# Altium schematic sheet CN032-1 Industry EtherCAT Servo Controller_MCU2.SchDoc
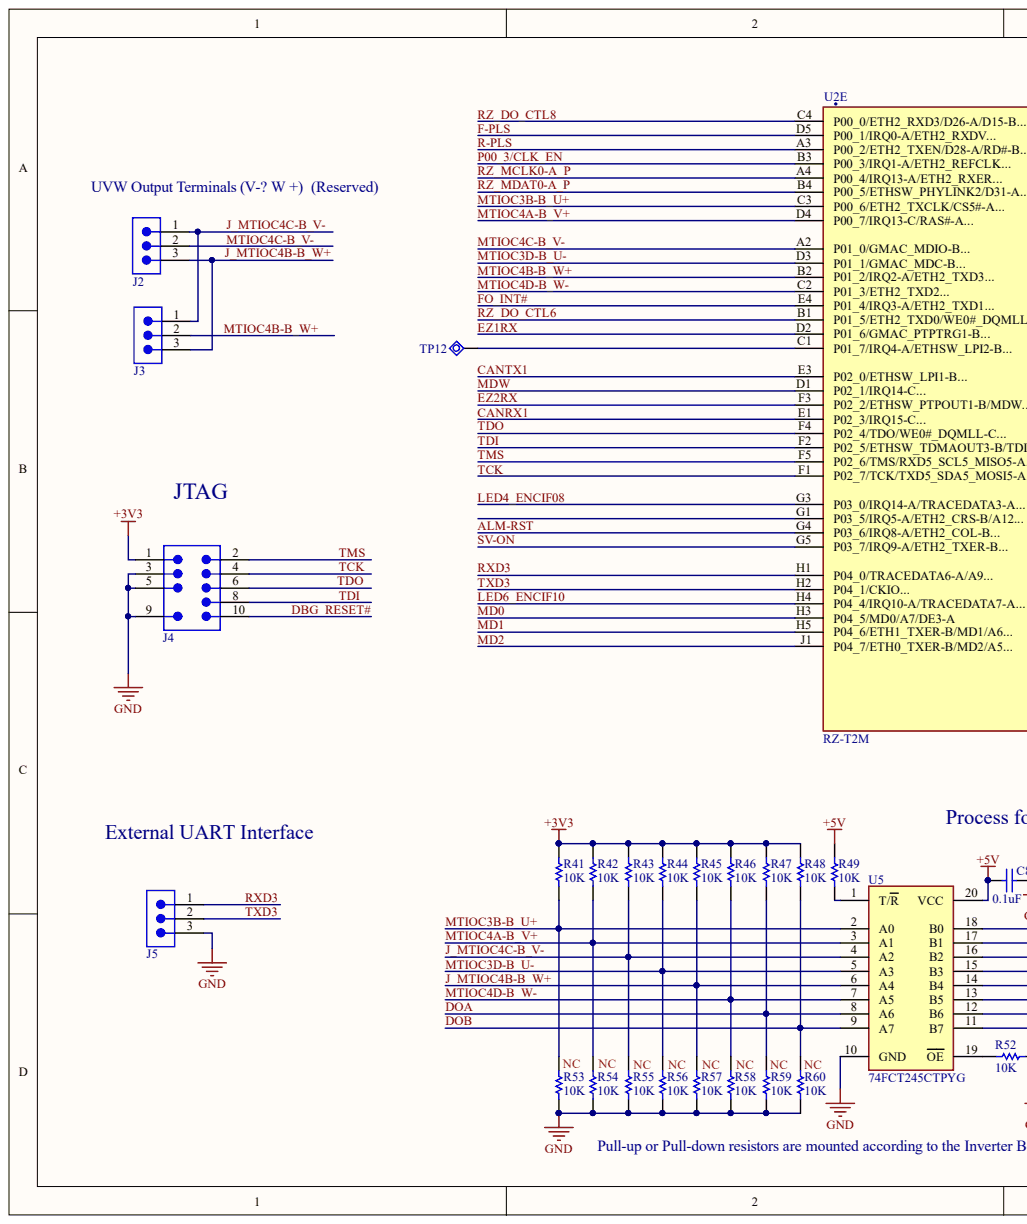
[diagram p0: CN032-1 Industry EtherCAT Servo Controller_MCU2.SchDoc, left]
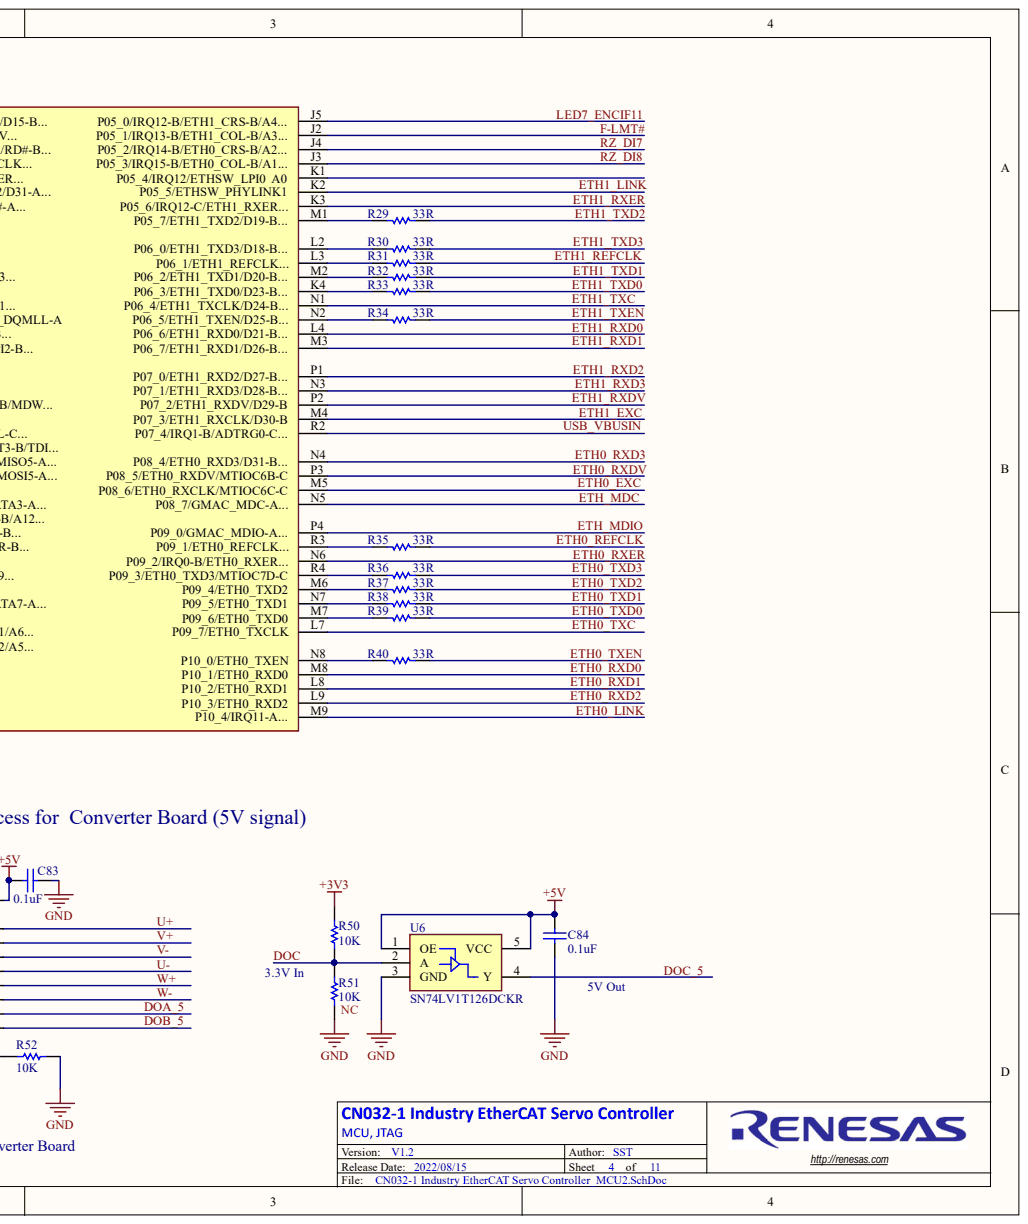
[diagram p1: CN032-1 Industry EtherCAT Servo Controller_MCU2.SchDoc, right]

## Parts
C83  0.1uF  [CAPC0402-01]
C84  0.1uF  [CAPC0402-01]
J2  2.54mm 1x3  [FCI_6800-x03]  (value not drawn)
J3  2.54mm 1x3  [FCI_6800-x03]  (value not drawn)
J4  1.27mm 2x5  [CONB132X60]  (value not drawn)
J5  2.54mm 1x3  [FCI_6800-x03]  (value not drawn)
R29  33R  [RESC0402-01]
R30  33R  [RESC0402-01]
R31  33R  [RESC0402-01]
R32  33R  [RESC0402-01]
R33  33R  [RESC0402-01]
R34  33R  [RESC0402-01]
R35  33R  [RESC0402-01]
R36  33R  [RESC0402-01]
R37  33R  [RESC0402-01]
R38  33R  [RESC0402-01]
R39  33R  [RESC0402-01]
R40  33R  [RESC0402-01]
R41  10K  [RESC0402-01]
R42  10K  [RESC0402-01]
R43  10K  [RESC0402-01]
R44  10K  [RESC0402-01]
R45  10K  [RESC0402-01]
R46  10K  [RESC0402-01]
R47  10K  [RESC0402-01]
R48  10K  [RESC0402-01]
R49  10K  [RESC0402-01]
R50  10K  [RESC0402-01]
R51  Resistance: 10K  [RESC0402-01]  (hidden comment: 10K/NC)
R52  10K  [RESC0402-01]
R53  Resistance: 10K  [RESC0402-01]  (hidden comment: 10K/NC)
R54  Resistance: 10K  [RESC0402-01]  (hidden comment: 10K/NC)
R55  Resistance: 10K  [RESC0402-01]  (hidden comment: 10K/NC)
R56  Resistance: 10K  [RESC0402-01]  (hidden comment: 10K/NC)
R57  Resistance: 10K  [RESC0402-01]  (hidden comment: 10K/NC)
R58  Resistance: 10K  [RESC0402-01]  (hidden comment: 10K/NC)
R59  Resistance: 10K  [RESC0402-01]  (hidden comment: 10K/NC)
R60  Resistance: 10K  [RESC0402-01]  (hidden comment: 10K/NC)
TP12  Test Point  [TEST POINT]  (value not drawn)
U2  RZ-T2M  [RZ-N2L_BGA225]  (part E)
U5  74FCT245CTPYG  [74FCT245CTPYG]
U6  SN74LV1T126DCKR  [SC-70-5]

## Nets
+3V3: J4.1 R41.1 R42.1 R43.1 R44.1 R45.1 R46.1 R47.1 R48.1 R50.1
+5V: C83.2 C84.2 R49.1 U5.20(VCC) U6.1(OE) U6.5(VCC)
GND: C83.1 C84.1 J4.3 J4.5 J4.9 J5.3 R51.1 R52.1 R53.1 R54.1 R55.1 R56.1 R57.1 R58.1 R59.1 R60.1 U5.10(GND) U6.3(GND)
ALM-RST: U2.G4(P03_6/IRQ8-A/ETH2_COL-B...)
CANRX1: U2.E1(P02_3/IRQ15-C...)
CANTX1: U2.E3(P02_0/ETHSW_LPI1-B...)
DBG_RESET#: J4.10
DOA: R47.2 R59.2 U5.8(A6)
DOA_5: U5.12(B6)
DOB: R48.2 R60.2 U5.9(A7)
DOB_5: U5.11(B7)
DOC: R50.2 R51.2 U6.2(A)
DOC_5: U6.4(Y)
ETH0_EXC: U2.M5(P08_6/ETH0_RXCLK/MTIOC6C-C)
ETH0_LINK: U2.M9(P10_4/IRQ11-A...)
ETH0_REFCLK: R35.1
ETH0_RXD0: U2.M8(P10_1/ETH0_RXD0)
ETH0_RXD1: U2.L8(P10_2/ETH0_RXD1)
ETH0_RXD2: U2.L9(P10_3/ETH0_RXD2)
ETH0_RXD3: U2.N4(P08_4/ETH0_RXD3/D31-B...)
ETH0_RXDV: U2.P3(P08_5/ETH0_RXDV/MTIOC6B-C)
ETH0_RXER: U2.N6(P09_2/IRQ0-B/ETH0_RXER...)
ETH0_TXC: U2.L7(P09_7/ETH0_TXCLK)
ETH0_TXD0: R39.1
ETH0_TXD1: R38.1
ETH0_TXD2: R37.1
ETH0_TXD3: R36.1
ETH0_TXEN: R40.1
ETH1_EXC: U2.M4(P07_3/ETH1_RXCLK/D30-B)
ETH1_LINK: U2.K2(P05_5/ETHSW_PHYLINK1)
ETH1_REFCLK: R31.1
ETH1_RXD0: U2.L4(P06_6/ETH1_RXD0/D21-B...)
ETH1_RXD1: U2.M3(P06_7/ETH1_RXD1/D26-B...)
ETH1_RXD2: U2.P1(P07_0/ETH1_RXD2/D27-B...)
ETH1_RXD3: U2.N3(P07_1/ETH1_RXD3/D28-B...)
ETH1_RXDV: U2.P2(P07_2/ETH1_RXDV/D29-B)
ETH1_RXER: U2.K3(P05_6/IRQ12-C/ETH1_RXER...)
ETH1_TXC: U2.N1(P06_4/ETH1_TXCLK/D24-B...)
ETH1_TXD0: R33.1
ETH1_TXD1: R32.1
ETH1_TXD2: R29.1
ETH1_TXD3: R30.1
ETH1_TXEN: R34.1
ETH_MDC: U2.N5(P08_7/GMAC_MDC-A...)
ETH_MDIO: U2.P4(P09_0/GMAC_MDIO-A...)
EZ1RX: U2.D2(P01_6/GMAC_PTPTRG1-B...)
EZ2RX: U2.F3(P02_2/ETHSW_PTPOUT1-B/MDW...)
F-LMT#: U2.J2(P05_1/IRQ13-B/ETH1_COL-B/A3...)
F-PLS: U2.D5(P00_1/IRQ0-A/ETH2_RXDV...)
FO_INT#: U2.E4(P01_4/IRQ3-A/ETH2_TXD1...)
J_MTIOC4B-B_W+: J2.3 J3.3 R45.2 R57.2 U5.6(A4)
J_MTIOC4C-B_V-: J2.1 J3.1 R43.2 R55.2 U5.4(A2)
LED4_ENCIF08: U2.G3(P03_0/IRQ14-A/TRACEDATA3-A...)
LED6_ENCIF10: U2.H4(P04_4/IRQ10-A/TRACEDATA7-A...)
LED7_ENCIF11: U2.J5(P05_0/IRQ12-B/ETH1_CRS-B/A4...)
MD0: U2.H3(P04_5/MD0/A7/DE3-A)
MD1: U2.H5(P04_6/ETH1_TXER-B/MD1/A6...)
MD2: U2.J1(P04_7/ETH0_TXER-B/MD2/A5...)
MDW: U2.D1(P02_1/IRQ14-C...)
MTIOC3B-B_U+: R41.2 R53.2 U2.C3(P00_6/ETH2_TXCLK/CS5#-A...) U5.2(A0)
MTIOC3D-B_U-: R44.2 R56.2 U2.D3(P01_1/GMAC_MDC-B...) U5.5(A3)
MTIOC4A-B_V+: R42.2 R54.2 U2.D4(P00_7/IRQ13-C/RAS#-A...) U5.3(A1)
MTIOC4B-B_W+: J3.2 U2.B2(P01_2/IRQ2-A/ETH2_TXD3...)
MTIOC4C-B_V-: J2.2 U2.A2(P01_0/GMAC_MDIO-B...)
MTIOC4D-B_W-: R46.2 R58.2 U2.C2(P01_3/ETH2_TXD2...) U5.7(A5)
P00_3/CLK_EN: U2.B3(P00_3/IRQ1-A/ETH2_REFCLK...)
R-PLS: U2.A3(P00_2/ETH2_TXEN/D28-A/RD#-B...)
RXD3: J5.1 U2.H1(P04_0/TRACEDATA6-A/A9...)
RZ_DI7: U2.J4(P05_2/IRQ14-B/ETH0_CRS-B/A2...)
RZ_DI8: U2.J3(P05_3/IRQ15-B/ETH0_COL-B/A1...)
RZ_DO_CTL6: U2.B1(P01_5/ETH2_TXD0/WE0#_DQMLL-A)
RZ_DO_CTL8: U2.C4(P00_0/ETH2_RXD3/D26-A/D15-B...)
RZ_MCLK0-A_P: U2.A4(P00_4/IRQ13-A/ETH2_RXER...)
RZ_MDAT0-A_P: U2.B4(P00_5/ETHSW_PHYLINK2/D31-A...)
SV-ON: U2.G5(P03_7/IRQ9-A/ETH2_TXER-B...)
TCK: J4.4 U2.F1(P02_7/TCK/TXD5_SDA5_MOSI5-A...)
TDI: J4.8 U2.F2(P02_5/ETHSW_TDMAOUT3-B/TDI...)
TDO: J4.6 U2.F4(P02_4/TDO/WE0#_DQMLL-C...)
TMS: J4.2 U2.F5(P02_6/TMS/RXD5_SCL5_MISO5-A...)
TXD3: J5.2 U2.H2(P04_1/CKIO...)
U+: U5.18(B0)
U-: U5.15(B3)
USB_VBUSIN: U2.R2(P07_4/IRQ1-B/ADTRG0-C...)
V+: U5.17(B1)
V-: U5.16(B2)
W+: U5.14(B4)
W-: U5.13(B5)
NetR29_2: R29.2 U2.M1(P05_7/ETH1_TXD2/D19-B...)
NetR30_2: R30.2 U2.L2(P06_0/ETH1_TXD3/D18-B...)
NetR31_2: R31.2 U2.L3(P06_1/ETH1_REFCLK...)
NetR32_2: R32.2 U2.M2(P06_2/ETH1_TXD1/D20-B...)
NetR33_2: R33.2 U2.K4(P06_3/ETH1_TXD0/D23-B...)
NetR34_2: R34.2 U2.N2(P06_5/ETH1_TXEN/D25-B...)
NetR35_2: R35.2 U2.R3(P09_1/ETH0_REFCLK...)
NetR36_2: R36.2 U2.R4(P09_3/ETH0_TXD3/MTIOC7D-C)
NetR37_2: R37.2 U2.M6(P09_4/ETH0_TXD2)
NetR38_2: R38.2 U2.N7(P09_5/ETH0_TXD1)
NetR39_2: R39.2 U2.M7(P09_6/ETH0_TXD0)
NetR40_2: R40.2 U2.N8(P10_0/ETH0_TXEN)
NetR49_2: R49.2 U5.1(T/R)
NetR52_2: R52.2 U5.19(OE)
NetTP12_1: TP12.1 U2.C1(P01_7/IRQ4-A/ETHSW_LPI2-B...)

Not connected: U2 G1(P03_5/IRQ5-A/ETH2_CRS-B/A12...) K1(A0)

## Library data of the parts
C83 C84 = CAP-0402-0.1uF-YAGEO: Ceramic Chip Capacitor 0402 0.1uF 16V; Manufacturer: YAGEO; Mfg Part Number: CC0402KPX7R7BB104; Dialectric: X7R; Tolerance: 10%; Capacitance: 0.1uF; Component Kind: Standard; Package: 0402; Voltage: 16V
  C83: Library Name: Synergy.IntLib
  C84: Library Name: Synergy.SchLib
J2 J3 J5 = CON-2.54mm 1x3: Header 0.1" pitch 3pos 1x3; Manufacturer: FCI; Mfg Part Number: 77311-818-03LF; Positions: 3; Component Kind: Standard; Pitch: 0.1"
  J2 J3: Library Name: Synergy.SchLib
  J5: Library Name: Synergy.IntLib
J4 = CON-1.27mm 2x5 MALE SMT: Pin header,RA,male,1.27pitch,2X5p,3H,2.5MM,GF 10u,Getrid 8th pin,Dip; Manufacturer: Samtec; Mfg Part Number: FTSH-105-01-L-DV-007-K; Component Kind: Standard; Part Type: Pin header; PCB Footprint: CONB132X60; Value: 1.27mm 2x5; Library Name: Synergy.SchLib
R29-R40 = RES-0402-33R: Chip Resistor Thick Film 0402 33R 5% 1/16W; Manufacturer: YAGEO; Mfg Part Number: RC0402JR-0733RL; Component Kind: Standard; Package: 0402; Power: 1/16W; Resistance: 33R; Tolerance: 5%; Library Name: Synergy.SchLib
R41-R60 = RES-0402-10K: Chip Resistor Thick Film 0402 10K 1% 1/16W; Manufacturer: YAGEO; Mfg Part Number: RC0402FR-0710KL; Component Kind: Standard; Package: 0402; Power: 1/16W; Tolerance: 1%; Library Name: Synergy.IntLib
  R41-R50 R52: Resistance: 10K
TP12 = CON-Test Point: Component Kind: Standard; Description: Test Point; Library Name: Synergy.SchLib
U2 = MCU-RZ-N2L: Renesas RZ-T2M MCU; Component Kind: Standard; Display Name: RZ-T2M; Manufacturer: Renesas; Library Name: Synergy.SchLib
U5 = ICN-74FCT245CTPYG: IC TXRX NON-INVERT 5.25V 20SSOP; Manufacturer: Renesas; Component Kind: Standard; Mfg Part Number: 74FCT245CTPYG; Package: 20-SSOP; Library Name: Synergy.IntLib
U6 = ICN-SN74LV1T126DCKR: IC BUF NON-INVERT 5.5V SC70-5; Manufacturer: TI; Component Kind: Standard; Display Name: SN74LV1T126DCKR; Mfg Part Number: SN74LV1T126DCKR; Package: SOT-23-5; Library Name: Synergy.SchLib
